# Altium schematic sheet Shutdown_Circuit.SchDoc(Shutdown_Circuit)
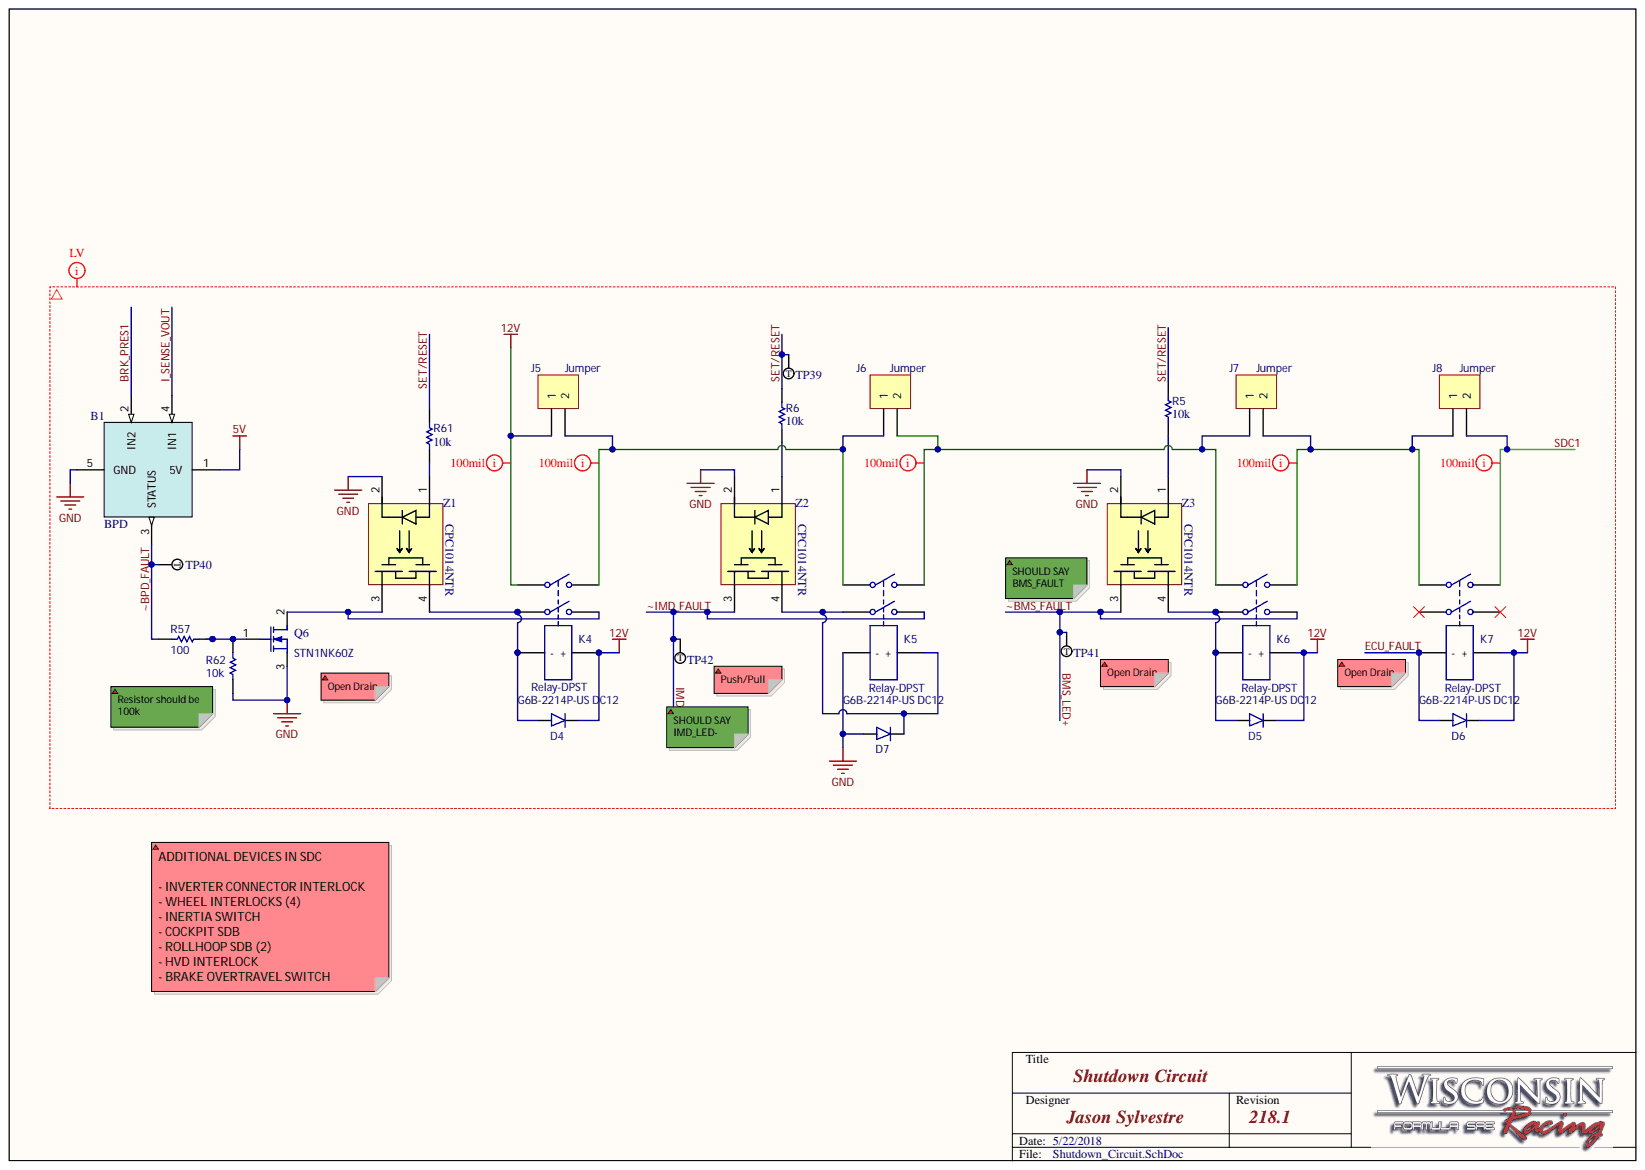
[diagram p0: Shutdown_Circuit.SchDoc]

## Parts
B1  BPD  [BPD]
D4  DIODE GEN PURP  [SMA]  (value not drawn)
D5  DIODE GEN PURP  [SMA]  (value not drawn)
D6  DIODE GEN PURP  [SMA]  (value not drawn)
D7  DIODE GEN PURP  [SMA]  (value not drawn)
J5  Jumper  [HDR1X2]
J6  Jumper  [HDR1X2]
J7  Jumper  [HDR1X2]
J8  Jumper  [HDR1X2]
K4  Relay-DPST  [RELAY_DSP2A]
K5  Relay-DPST  Manufacturer Part Number 1: G6B-2214P-US DC12  [RELAY_DSP2A]
K6  Relay-DPST  [RELAY_DSP2A]
K7  Relay-DPST  [RELAY_DSP2A]
Q6  Manufacturer Part Number: STN1NK60Z  [SOT223-4L]  (hidden comment: MOSFET-N)
R5  Resistance: 10k  [RESC1608N]  (hidden comment: Res1)
R6  Resistance: 10k  [RESC1608N]  (hidden comment: Res1)
R57  Res1  [RESC1608N]  (value not drawn)
R61  Resistance: 10k  [RESC1608N]  (hidden comment: Res1)
R62  Resistance: 10k  [RESC1608N]  (hidden comment: Res1)
TP39  Test Point  (value not drawn)
TP40  Test Point  (value not drawn)
TP41  Test Point  (value not drawn)
TP42  Test Point  (value not drawn)
Z1  Manufacturer Part Number: CPC1014NTR  [SOP-4]  (hidden comment: SSR CPC1014NTR)
Z2  Manufacturer Part Number: CPC1014NTR  [SOP-4]  (hidden comment: SSR CPC1014NTR)
Z3  Manufacturer Part Number: CPC1014NTR  [SOP-4]  (hidden comment: SSR CPC1014NTR)

## Nets
5V: B1.1(5V)
12V: D4.2 D5.2 D6.2 J5.1 K4.6 K4.8(+) K6.8(+) K7.8(+)
GND: B1.5(GND) D7.1 K5.1(-) Q6.3 R62.1 Z1.2 Z2.2 Z3.2
BMS_LED+: K6.4 TP41.1 Z3.3
BRK_PRES1: B1.2(IN2)
ECU_FAULT: D6.1 K7.1(-)
I_SENSE_VOUT: B1.4(IN1)
IMD_LED+: K5.4 TP42.1 Z2.3
SDC1: J8.2 K7.5
SET/RESET: R5.2 R6.2 R61.2 TP39.1
~BPD_FAULT: B1.3(STATUS) R57.2 TP40.1
NetD4_1: D4.1 K4.1(-) K4.3 Z1.4
NetD5_1: D5.1 K6.1(-) K6.3 Z3.4
NetD7_2: D7.2 K5.3 K5.8(+) Z2.4
NetJ5_2: J5.2 J6.1 K4.5 K5.6
NetJ6_2: J6.2 J7.1 K5.5 K6.6
NetJ7_2: J7.2 J8.1 K6.5 K7.6
NetK4_4: K4.4 Q6.2 Z1.3
NetQ6_1: Q6.1 R57.1 R62.2
NetR5_1: R5.1 Z3.1
NetR6_1: R6.1 Z2.1
NetR61_1: R61.1 Z1.1
N00223:

Not connected: K7 3 4

## Library data of the parts
B1 = BPD: Brake Plausibility Device; Library Name: Formula_Library.SchLib; Component Kind: Standard
D4-D7 = Diode US1MHE3_A/H: DIODE GEN PURP 1KV 1A DO214AC; Manufacturer 1: Vishay Semiconductor Diodes Division; Voltage - Forward (Vf) (Max) @ If: 1.7V @ 1A; Voltage - DC Reverse (Vr) (Max): 1000V (1kV); Speed: Fast Recovery =< 500ns, > 200mA (Io); Reverse Recovery Time (trr): 75ns; Diode Type: Standard; Current - Reverse Leakage @ Vr: 10µA @ 1000V; Category 1: Discrete Semiconductor Products; Capacitance @ Vr, F: 10pF @ 4V, 1MHz; Supplier Part Number 1: US1MHE3_A/HGICT-ND; Supplier Device Package: DO-214AC (SMA); Supplier 1: Digi-Key; Stock 1: 35748; RoHS: RoHS Compliant; Pricing 1: 1=0.43, 10=0.35, 25=0.3208, 100=0.2386, 250=0.21624, 500=0.17896 (USD); Packaging: Cut Tape (CT); Package / Case: DO-214AC, SMA; Operating Temperature - Junction: -55°C ~ 150°C; Mounting Type: Surface Mount; Manufacturer Part Number 1: US1MHE3_A/H; Library Name: Formula_Library.SchLib; Description 1: DIODE GEN PURP 1KV 1A DO214AC; Current - Average Rectified (Io): 1A; ComponentLink1URL: http://www.vishay.com/docs/88768/us1.pdf; ComponentLink1Description: http://www.vishay.com/docs/88768/us1.pdf; Component Kind: Standard
J5-J8 = Jumper: 2 pin header; Manufacturer Part Number 1: 961102-6404-AR; Manufacturer 1: 3M; Pitch: 0.100" (2.54mm); Number of Positions Loaded: All; Number of Positions: 2; Description 1: CONN HEADER VERT SGL 2POS GOLD; Connector Type: Header, Unshrouded; Category 1: Connectors, Interconnects; Termination: Solder; Supplier Part Number 1: 3M9447-ND; Supplier 1: Digi-Key; Stock 1: 171514; Row Spacing: -; RoHS: RoHS Compliant; Pricing 1: 1=0.16, 10=0.15, 25=0.128, 50=0.112, 100=0.104, 250=0.092, 500=0.088, 1000=0.072, 2500=0.066 (USD); Packaging: Bulk; Number of Rows: 1; Mounting Type: Through Hole; Library Name: Formula_Library.SchLib; Features: -; Fastening Type: -; Contact Type: Male Pin; Contact Mating Length: 0.217" (5.50mm); Contact Finish Thickness: Flash; Contact Finish: Gold; ComponentLink1URL: http://multimedia.3m.com/mws/media/548112O/3mtm-pin-strip-header-ts2181.pdf; ComponentLink1Description: http://multimedia.3m.com/mws/media/548112O/3mtm-pin-strip-header-ts2181.pdf; Component Kind: Standard; Color: Black
K4 K6 K7 = Relay-DPST: Dual-Pole Single-Throw Relay; Manufacturer Part Number 1: G6B-2214P-US DC12; Manufacturer 1: Omron Electronics Inc-EMC Div; Turn On Voltage (Max): 9.6 VDC; Turn Off Voltage (Min): 1.2 VDC; Switching Voltage: 380VAC, 125VDC - Max; Release Time: 10ms; Relay Type: General Purpose; Description 1: RELAY GEN PURPOSE DPST 5A 12V; Contact Rating (Current): 5A; Contact Form: DPST (2 Form A); Coil Voltage: 12VDC; Coil Type: Non Latching; Coil Resistance: 480 Ohms; Coil Power: 300 mW; Coil Current: 25mA; Category 1: Relays; Termination Style: PC Pin; Supplier Part Number 1: Z759-ND; Supplier 1: Digi-Key; Stock 1: 766; Simulation: DPSTRELAY; RoHS: RoHS Compliant; Pricing 1: 1=7.06, 10=6.617, 25=5.882, 50=5.588, 100=5.2938, 250=4.7056, 500=4.4115, 1000=4.1174, 2500=4.04388 (USD); Packaging: Bulk; PackageReference: MODULE6; Operating Temperature: -25°C ~ 70°C; Operate Time: 10ms; Mounting Type: Through Hole; Library Name: Formula_Library.SchLib; Features: Sealed - Fully; Contact Material: Silver Alloy, Cadmium Free; ComponentLink1URL: https://www.omron.com/ecb/products/pdf/en-g6b.pdf; ComponentLink1Description: https://www.omron.com/ecb/products/pdf/en-g6b.pdf; Component Kind: Standard; Publisher: Altium Limited
  K6 K7: Published: 24-Mar-1999; LatestRevisionNote: Re-released for DXP Platform.; LatestRevisionDate: 17-Jul-2002
K5 = Relay-DPST: Dual-Pole Single-Throw Relay; Manufacturer 1: Omron Electronics Inc-EMC Div; Turn On Voltage (Max): 9.6 VDC; Turn Off Voltage (Min): 1.2 VDC; Switching Voltage: 380VAC, 125VDC - Max; Release Time: 10ms; Relay Type: General Purpose; Description 1: RELAY GEN PURPOSE DPST 5A 12V; Contact Rating (Current): 5A; Contact Form: DPST (2 Form A); Coil Voltage: 12VDC; Coil Type: Non Latching; Coil Resistance: 480 Ohms; Coil Power: 300 mW; Coil Current: 25mA; Category 1: Relays; Termination Style: PC Pin; Supplier Part Number 1: Z759-ND; Supplier 1: Digi-Key; Stock 1: 766; Simulation: DPSTRELAY; RoHS: RoHS Compliant; Published: 24-Mar-1999; Pricing 1: 1=7.06, 10=6.617, 25=5.882, 50=5.588, 100=5.2938, 250=4.7056, 500=4.4115, 1000=4.1174, 2500=4.04388 (USD); Packaging: Bulk; PackageReference: MODULE6; Operating Temperature: -25°C ~ 70°C; Operate Time: 10ms; Mounting Type: Through Hole; Library Name: Formula_Library.SchLib; LatestRevisionNote: Re-released for DXP Platform.; LatestRevisionDate: 17-Jul-2002; Features: Sealed - Fully; Contact Material: Silver Alloy, Cadmium Free; ComponentLink1URL: https://www.omron.com/ecb/products/pdf/en-g6b.pdf; ComponentLink1Description: https://www.omron.com/ecb/products/pdf/en-g6b.pdf; Component Kind: Standard; Publisher: Altium Limited
Q6 = MOSFET-N: N-Channel MOSFET; Manufacturer: STMicroelectronics; Voltage Rating (DC): 600V; Turn-On Delay Time: 5.5ns; Turn-Off Delay Time: 13ns; Threshold Voltage: 3.75V; Rise Time: 5ns; Rds On Max: 15R; Power Dissipation: 3.3W; Number of Elements: 1; Input Capacitance: 94pF; Gate to Source Voltage (Vgs): 30V; Fall Time: 18ns; Element Configuration: Single; Current Rating: 250mA; Continuous Drain Current (ID): 300mA; Width: 3.5mm; Weight: 0.01lb; Supplier Part Number 1: 497-3523-6-ND; Supplier 1: Digi-Key; Stock 1: 39853; Simulation: NMOS; REACH SVHC: No SVHC; Radiation Hardening: No; Pricing 1: 1=0.67, 10=0.587, 100=0.45, 500=0.3558, 1000=0.2846 (USD); Packaging: Tape and Reel; Package Quantity: 4000; Number of Pins: 4; Mount: Surface Mount; Min Operating Temperature: -55°C; Max Power Dissipation: 3.3W; Max Operating Temperature: 150°C; Library Name: Formula_Library.SchLib; Length: 6.5mm; Lead Free: Lead Free; Height: 1.8mm; Drain to Source Voltage (Vdss): 600V; Drain to Source Resistance: 15R; Drain to Source Breakdown Voltage: 600V; ComponentLink5URL: https://datasheet.ciiva.com/26911/getdatasheetpartid-74454-26911934.pdf; ComponentLink5Description: https://datasheet.ciiva.com/26911/getdatasheetpartid-74454-26911934.pdf; ComponentLink4URL: https://datasheet.ciiva.com/22712/1786014-22712606.pdf; ComponentLink4Description: https://datasheet.ciiva.com/22712/1786014-22712606.pdf; ComponentLink3URL: https://datasheet.ciiva.com/4441/cd00003347-42870-4441147.pdf; ComponentLink3Description: https://datasheet.ciiva.com/4441/cd00003347-42870-4441147.pdf; ComponentLink2URL: https://datasheet.ciiva.com/16449/cd00003347-16449159.pdf; ComponentLink2Description: https://datasheet.ciiva.com/16449/cd00003347-16449159.pdf; ComponentLink1URL: https://datasheet.ciiva.com/8025/cd00003347-8025266.pdf; ComponentLink1Description: https://datasheet.ciiva.com/8025/cd00003347-8025266.pdf; Component Kind: Standard; Case/Package: SOT-223
R5 R6 R61 R62 = Res1: 10K resistor; Manufacturer Part Number: ERJ-3GEYJ103V; Manufacturer: Panasonic / Panasonic ECG; Tolerance (%): ±5 %; Temp Coefficient: ±200 ppm/°C; Rated Power: 0.1 W; Supplier Part Number 1: P10KGCT-ND; Supplier 1: Digikey; Stock 1: 6640574; Size Code: 0603; Simulation: RESISTOR; Signal Integrity: Res; Pricing 1: 1=0.1, 10=0.016, 25=0.0116, 50=0.0088, 100=0.0065, 250=0.005, 500=0.004, 1000=0.0029 (USD); Library Name: Miscellaneous Devices.IntLib; ComponentLink11URL: https://datasheet.ciiva.com/48531/aoa0000ce1-48531887.pdf; ComponentLink11Description: https://datasheet.ciiva.com/48531/aoa0000ce1-48531887.pdf; ComponentLink10URL: https://datasheet.ciiva.com/16740/aoa0000ce1-16740655.pdf; ComponentLink10Description: https://datasheet.ciiva.com/16740/aoa0000ce1-16740655.pdf; ComponentLink9URL: https://datasheet.ciiva.com/11809/0900766b80f806dd-11809566.pdf; ComponentLink9Description: https://datasheet.ciiva.com/11809/0900766b80f806dd-11809566.pdf; ComponentLink8URL: https://datasheet.ciiva.com/9117/aoa0000ce1-9117038.pdf; ComponentLink8Description: https://datasheet.ciiva.com/9117/aoa0000ce1-9117038.pdf; ComponentLink7URL: https://datasheet.ciiva.com/34303/2007265-34303757.pdf; ComponentLink7Description: https://datasheet.ciiva.com/34303/2007265-34303757.pdf; ComponentLink6URL: https://datasheet.ciiva.com/17212/aoa0000ce1-17212106.pdf; ComponentLink6Description: https://datasheet.ciiva.com/17212/aoa0000ce1-17212106.pdf; ComponentLink5URL: https://datasheet.ciiva.com/46385/1678796-46385240.pdf; ComponentLink5Description: https://datasheet.ciiva.com/46385/1678796-46385240.pdf; ComponentLink4URL: https://datasheet.ciiva.com/24133/aoa0000ce1-37422-24133064.pdf; ComponentLink4Description: https://datasheet.ciiva.com/24133/aoa0000ce1-37422-24133064.pdf; ComponentLink3URL: https://datasheet.ciiva.com/46371/1678796-46371909.pdf; ComponentLink3Description: https://datasheet.ciiva.com/46371/1678796-46371909.pdf; ComponentLink2URL: https://datasheet.ciiva.com/30147/4aoa0000ce1-30147029.pdf; ComponentLink2Description: https://datasheet.ciiva.com/30147/4aoa0000ce1-30147029.pdf; ComponentLink1URL: https://datasheet.ciiva.com/28514/1678796-28514213.pdf; ComponentLink1Description: https://datasheet.ciiva.com/28514/1678796-28514213.pdf; Component Kind: Standard
R57 = Res1: 1.5K resistor; Manufacturer Part Number: RC0603FR-07100RL; Manufacturer: Yageo; Voltage Rating (DC): 50V; Tolerance: 1%; Temperature Coefficient: 100ppm/°C; Resistance: 100; Power Rating: 100mW; Width: 0.8mm; Voltage Rating: 50V; Termination: SMD/SMT; Supplier Part Number 1: 311-100HRCT-ND; Supplier 1: Digikey; Stock 1: 6020435; Simulation: RESISTOR; Signal Integrity: Res; REACH SVHC: Unknown; Pricing 1: 1=0.1, 10=0.015, 100=0.006, 1000=0.0027, 2500=0.0023 (USD); Packaging: Tape and Reel; Number of Pins: 2; Min Operating Temperature: -55°C; Military Standard: Not; Max Operating Temperature: 155°C; Library Name: Miscellaneous Devices.IntLib; Length: 1.6mm; Lead Free: Lead Free; Height: 0.45mm; Depth: 0.8mm; Composition: Thick Film; ComponentLink7URL: https://datasheet.ciiva.com/22747/443971-22747518.pdf; ComponentLink7Description: https://datasheet.ciiva.com/22747/443971-22747518.pdf; ComponentLink6URL: https://datasheet.ciiva.com/7746/1738695-7746238.pdf; ComponentLink6Description: https://datasheet.ciiva.com/7746/1738695-7746238.pdf; ComponentLink5URL: https://datasheet.ciiva.com/7746/1738695-7746237.pdf; ComponentLink5Description: https://datasheet.ciiva.com/7746/1738695-7746237.pdf; ComponentLink4URL: https://datasheet.ciiva.com/7746/1686128-7746236.pdf; ComponentLink4Description: https://datasheet.ciiva.com/7746/1686128-7746236.pdf; ComponentLink3URL: https://datasheet.ciiva.com/46440/1868787-46440890.pdf; ComponentLink3Description: https://datasheet.ciiva.com/46440/1868787-46440890.pdf; ComponentLink2URL: https://datasheet.ciiva.com/11808/0900766b80f96399-11808280.pdf; ComponentLink2Description: https://datasheet.ciiva.com/11808/0900766b80f96399-11808280.pdf; ComponentLink1URL: https://datasheet.ciiva.com/7660/38590-7660788.pdf; ComponentLink1Description: https://datasheet.ciiva.com/7660/38590-7660788.pdf; Component Kind: Standard; Case Code (Metric): 1608; Case Code (Imperial): 0603; Case/Package: 0603
TP39-TP42 = Test Point: Material - Insulation: No Insulation; Type: PC Test Point; Supplier Part Number 1: A106145CT-ND; Supplier 1: Digi-Key; Stock 1: 136646; Size / Dimension: 0.063" x 0.031" x 0.045" (1.60mm x 0.80mm x 1.15mm); RoHS: RoHS Compliant; Pricing 1: 1=0.22, 10=0.206, 25=0.1756, 50=0.1536, 100=0.1426, 250=0.12616, 500=0.12068, 1000=0.09874 (USD); Plating: -; Part Status: Active; Packaging: Cut Tape (CT); Mounting Type: Surface Mount; Material - Body: -; Library Name: Formula_Library.SchLib; Description: Test Point; ComponentLink1URL: http://www.te.com/commerce/DocumentDelivery/DDEController?Action=srchrtrv&DocNm=1773266&DocType=DS&DocLang=English; ComponentLink1Description: http://www.te.com/commerce/DocumentDelivery/DDEController?Action=srchrtrv&DocNm=1773266&DocType=DS&DocLang=English; Component Kind: Standard; Color: Natural; Category: Test and Measurement
Z1-Z3 = SSR CPC1014NTR: Solid State Relay SPST-NO CPC1014NTR; Manufacturer: Ixys Clare; Throw Configuration: SPST; Output Current: 400mA; On-State Resistance: 2R; Max Output Voltage: 60V; Max Input Current: 50mA; Isolation Voltage: 1.5kV; Width: 3.8mm; Termination: Gull Wing; Supplier Part Number 1: CLA314CT-ND; Supplier 1: Digi-Key; Relay Type: Relay; Radiation Hardening: No; Pricing 1: 1=1.77, 10=1.602, 25=1.43, 100=1.287, 250=1.144, 500=1.001, 1000=0.8294 (USD); Packaging: Tape and Reel; Package Quantity: 1; Number of Pins: 4; Mount: Surface Mount; Min Operating Temperature: -40°C; Max Output Current: 400mA; Max Operating Temperature: 85°C; Load Current: 400mA; Library Name: Formula_Library.SchLib; Length: 4.089mm; Lead Free: Lead Free; Input Current: 50mA; Height: 2.184mm; ComponentLink5URL: https://datasheet.ciiva.com/2421/cpc1014n-2421261.pdf; ComponentLink5Description: https://datasheet.ciiva.com/2421/cpc1014n-2421261.pdf; ComponentLink4URL: https://datasheet.ciiva.com/15709/cpc1014n-15709004.pdf; ComponentLink4Description: https://datasheet.ciiva.com/15709/cpc1014n-15709004.pdf; ComponentLink3URL: https://datasheet.ciiva.com/2421/cpc1014n-2421260.pdf; ComponentLink3Description: https://datasheet.ciiva.com/2421/cpc1014n-2421260.pdf; ComponentLink2URL: https://datasheet.ciiva.com/2421/cpc1014n-2421259.pdf; ComponentLink2Description: https://datasheet.ciiva.com/2421/cpc1014n-2421259.pdf; ComponentLink1URL: https://datasheet.ciiva.com/16393/cpc1014n-16393176.pdf; ComponentLink1Description: https://datasheet.ciiva.com/16393/cpc1014n-16393176.pdf; Component Kind: Standard; Case/Package: SOP
